# Altium schematic sheet VUB300.SchDoc
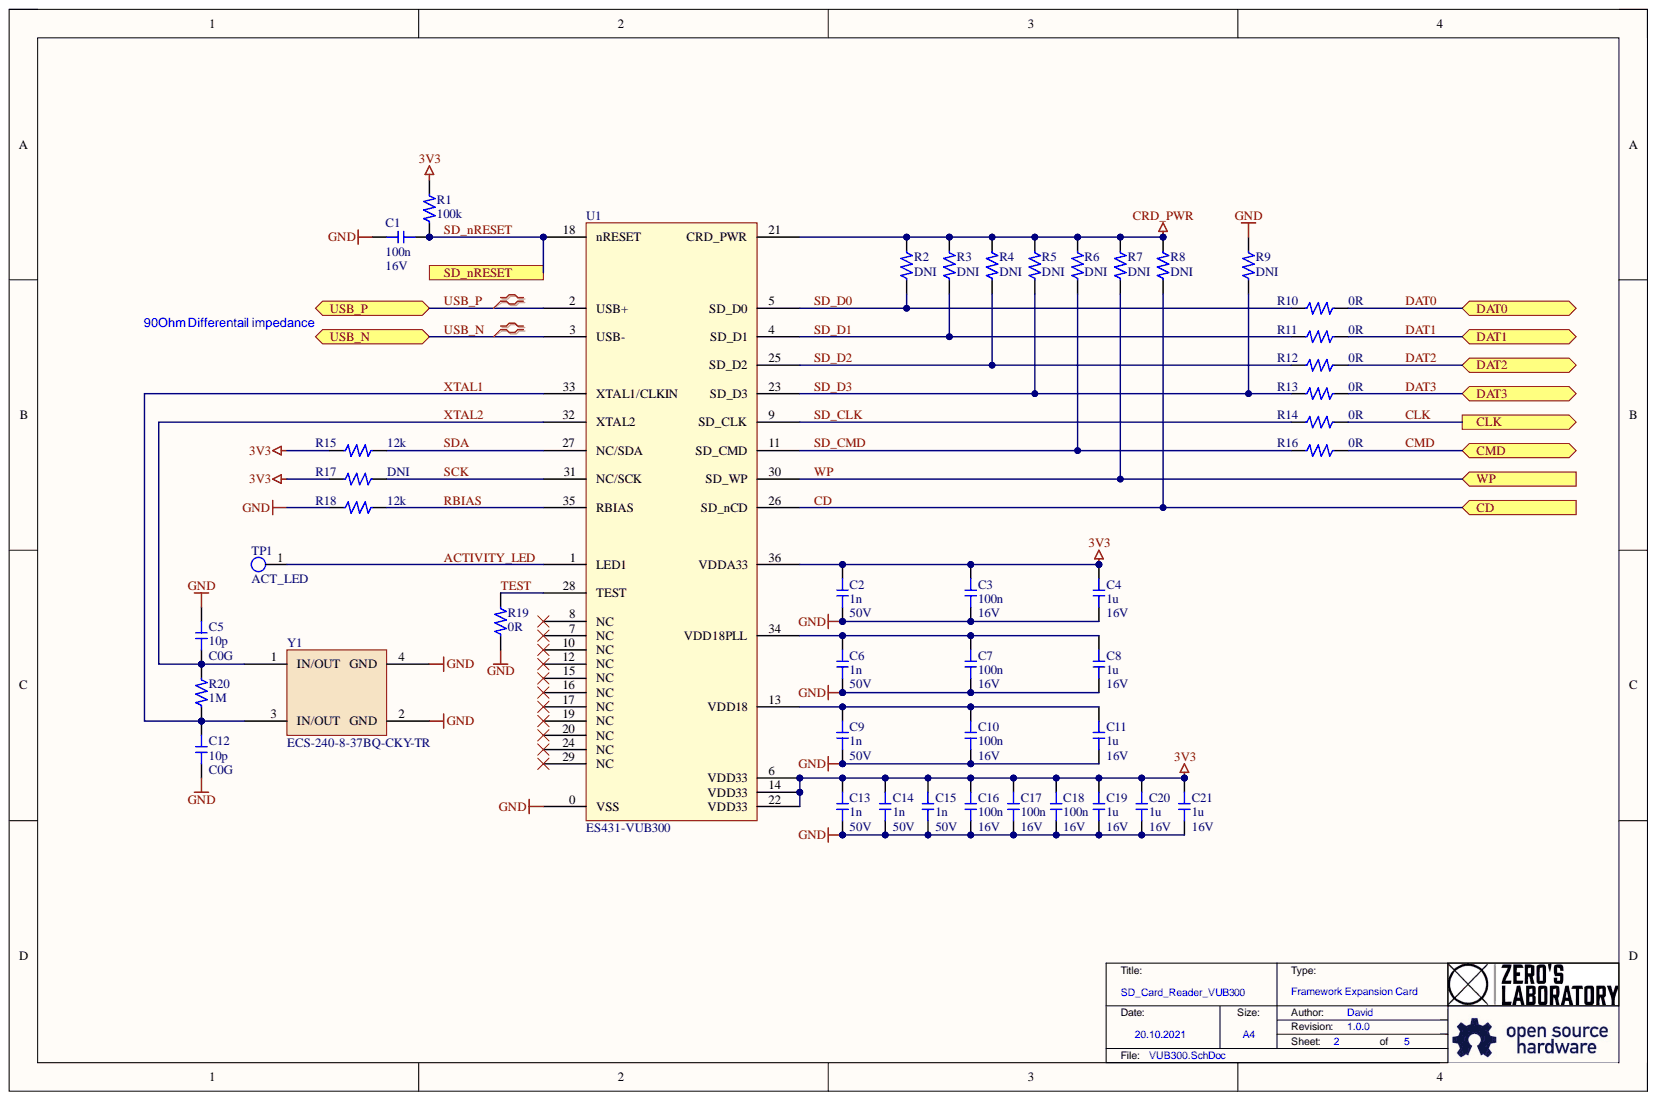
[diagram p0: VUB300.SchDoc]

## Parts
C1  100n  Voltage: 16V  [[0402]_CAPC100X50X50L25]
C2  1n  Voltage: 50V  [[0402]_CAPC100X50X50L25]
C3  100n  Voltage: 16V  [[0402]_CAPC100X50X50L25]
C4  1u  Voltage: 16V  [[0603]_CAPC160X80X100L40]
C5  10p  Dielectric: C0G  [[0402]_CAPC100X50X50L25]
C6  1n  Voltage: 50V  [[0402]_CAPC100X50X50L25]
C7  100n  Voltage: 16V  [[0402]_CAPC100X50X50L25]
C8  1u  Voltage: 16V  [[0603]_CAPC160X80X100L40]
C9  1n  Voltage: 50V  [[0402]_CAPC100X50X50L25]
C10  100n  Voltage: 16V  [[0402]_CAPC100X50X50L25]
C11  1u  Voltage: 16V  [[0603]_CAPC160X80X100L40]
C12  10p  Dielectric: C0G  [[0402]_CAPC100X50X50L25]
C13  1n  Voltage: 50V  [[0402]_CAPC100X50X50L25]
C14  1n  Voltage: 50V  [[0402]_CAPC100X50X50L25]
C15  1n  Voltage: 50V  [[0402]_CAPC100X50X50L25]
C16  100n  Voltage: 16V  [[0402]_CAPC100X50X50L25]
C17  100n  Voltage: 16V  [[0402]_CAPC100X50X50L25]
C18  100n  Voltage: 16V  [[0402]_CAPC100X50X50L25]
C19  1u  Voltage: 16V  [[0603]_CAPC160X80X100L40]
C20  1u  Voltage: 16V  [[0603]_CAPC160X80X100L40]
C21  1u  Voltage: 16V  [[0603]_CAPC160X80X100L40]
R1  100k  [[0402]_RESC100X50X35L25]
R2  DNI  [[0402]_RESC100X50X35L25]
R3  DNI  [[0402]_RESC100X50X35L25]
R4  DNI  [[0402]_RESC100X50X35L25]
R5  DNI  [[0402]_RESC100X50X35L25]
R6  DNI  [[0402]_RESC100X50X35L25]
R7  DNI  [[0402]_RESC100X50X35L25]
R8  DNI  [[0402]_RESC100X50X35L25]
R9  DNI  [[0402]_RESC100X50X35L25]
R10  0R  [[0402]_RESC100X50X35L25]
R11  0R  [[0402]_RESC100X50X35L25]
R12  0R  [[0402]_RESC100X50X35L25]
R13  0R  [[0402]_RESC100X50X35L25]
R14  0R  [[0402]_RESC100X50X35L25]
R15  12k  [[0402]_RESC100X50X35L25]
R16  0R  [[0402]_RESC100X50X35L25]
R17  DNI  [[0402]_RESC100X50X35L25]
R18  12k  [[0402]_RESC100X50X35L25]
R19  0R  [[0402]_RESC100X50X35L25]
R20  1M  [[0402]_RESC100X50X35L25]
TP1  ACT_LED  [TP_0.8MM_SMD]  (no BOM)
U1  ES431-VUB300
Y1  ECS-240-8-37BQ-CKY-TR

## Ports
CD, CLK, CMD, DAT0, DAT1, DAT2, DAT3, SD_nRESET, USB_N, USB_P, WP

## Nets
3V3: C2.2 C3.2 C4.2 C13.2 C14.2 C15.2 C16.2 C17.2 C18.2 C19.2 C20.2 C21.2 R1.2 R15.1 R17.1 U1.6(VDD33) U1.14(VDD33) U1.22(VDD33) U1.36(VDDA33)
CRD_PWR: R2.2 R3.2 R4.2 R5.2 R6.2 R7.2 R8.2 U1.21(CRD_PWR)
GND: C1.2 C2.1 C3.1 C4.1 C5.1 C6.1 C7.1 C8.1 C9.1 C10.1 C11.1 C12.2 C13.1 C14.1 C15.1 C16.1 C17.1 C18.1 C19.1 C20.1 C21.1 R9.2 R18.1 R19.1 U1.0(VSS) Y1.2(GND) Y1.4(GND)
ACTIVITY_LED: TP1.1 U1.1(LED1)
CD: R8.1 U1.26(SD_nCD) ; port CD
CLK: R14.2 ; port CLK
CMD: R16.2 ; port CMD
DAT0: R10.2 ; port DAT0
DAT1: R11.2 ; port DAT1
DAT2: R12.2 ; port DAT2
DAT3: R13.2 ; port DAT3
RBIAS: R18.2 U1.35(RBIAS)
SCK: R17.2 U1.31(NC/SCK)
SD_CLK: R14.1 U1.9(SD_CLK)
SD_CMD: R6.1 R16.1 U1.11(SD_CMD)
SD_D0: R2.1 R10.1 U1.5(SD_D0)
SD_D1: R3.1 R11.1 U1.4(SD_D1)
SD_D2: R4.1 R12.1 U1.25(SD_D2)
SD_D3: R5.1 R9.1 R13.1 U1.23(SD_D3)
SD_nRESET: C1.1 R1.1 U1.18(nRESET) ; port SD_nRESET
SDA: R15.2 U1.27(NC/SDA)
TEST: R19.2 U1.28(TEST)
USB_N: U1.3(USB-) ; port USB_N
USB_P: U1.2(USB+) ; port USB_P
WP: R7.1 U1.30(SD_WP) ; port WP
XTAL1: C12.1 R20.2 U1.33(XTAL1/CLKIN) Y1.3(IN/OUT)
XTAL2: C5.2 R20.1 U1.32(XTAL2) Y1.1(IN/OUT)
NetC6_2: C6.2 C7.2 C8.2 U1.34(VDD18PLL)
NetC9_2: C9.2 C10.2 C11.2 U1.13(VDD18)

Not connected: U1 7(NC) 8(NC) 10(NC) 12(NC) 15(NC) 16(NC) 17(NC) 19(NC) 20(NC) 24(NC) 29(NC)

## Library data of the parts
C1 C3 C7 C10 C16-C18 = Capacitor: Manufacturer: CAL-CHIP ELECTRONICS; MPN: GMC04X7R104K16NT; Capacitance: 100nF; Dielectric: X7R; Tolerance: ±10%; Type: Ceramic; Component Kind: Standard; Description: Capacitor; Library Name: Capacitor.SchLib; Operating Temperature [°C]: -55 to +125; Package: 0402[1005]; Package Type: SMD; Size: 1.00mm x 0.50mm; Value: 100n
C2 C6 C9 C13-C15 = Capacitor: Manufacturer: CAL-CHIP ELECTRONICS; MPN: GMC04X7R102K50NT; Capacitance: 1nF; Dielectric: X7R; Tolerance: ±10%; Type: Ceramic; Component Kind: Standard; Description: Capacitor; Library Name: Capacitor.SchLib; Operating Temperature [°C]: -55 to +125; Package: 0402[1005]; Package Type: SMD; Size: 1.00mm x 0.50mm; Value: 1n
C4 C8 C11 C19-C21 = Capacitor: Manufacturer: CAL-CHIP ELECTRONICS; MPN: GMC10X7R105K16NT; Capacitance: 1uF; Dielectric: X7R; Tolerance: ±10%; Type: Ceramic; Component Kind: Standard; Description: Capacitor; Library Name: Capacitor.SchLib; Operating Temperature [°C]: -55 to +125; Package: 0603[1608]; Package Type: SMD; Size: 1.60mm x 0.80mm; Value: 1u
C5 C12 = Capacitor: Manufacturer: CAL-CHIP ELECTRONICS; MPN: GMC04CG100J50NT; Capacitance: 10pF; Tolerance: ±5%; Type: Ceramic; Voltage: 50V; Component Kind: Standard; Description: Capacitor; Library Name: Capacitor.SchLib; Operating Temperature [°C]: -55 to +155; Package: 0402[1005]; Package Type: SMD; Size: 1.00mm x 0.50mm; Value: 10p
R1 = Resistor: Rated Power: 62.5mW; Resistance: 100kΩ; Tolerance: ±1%; Voltage: 50V; Component Kind: Standard; Description: Resistor; Library Name: Resistor.SchLib; Operating Temperature [°C]: -55 to +155; Package: 0402[1005]; Package Type: SMD; Size: 1.00mm x 0.50mm; Value: 100k
R2-R8 = Resistor: Rated Power: 62.5mW; Resistance: 49.9kΩ; Tolerance: ±1%; Value: 49k9; Voltage: 50V; Component Kind: Standard; Description: Resistor; Library Name: Resistor.SchLib; Operating Temperature [°C]: -55 to +155; Package: 0402[1005]; Package Type: SMD; Size: 1.00mm x 0.50mm
R9 = Resistor: Rated Power: 62.5mW; Resistance: 360kΩ; Tolerance: ±1%; Value: 360k; Voltage: 50V; Component Kind: Standard; Description: Resistor; Library Name: Resistor.SchLib; Operating Temperature [°C]: -55 to +155; Package: 0402[1005]; Package Type: SMD; Size: 1.00mm x 0.50mm
R10-R14 R16 R19 = Resistor: Rated Power: 62.5mW; Resistance: 0Ω; Tolerance: ±1%; Voltage: 50V; Component Kind: Standard; Description: Resistor; Library Name: Resistor.SchLib; Operating Temperature [°C]: -55 to +155; Package: 0402[1005]; Package Type: SMD; Size: 1.00mm x 0.50mm; Value: 0R
R15 R18 = Resistor: Rated Power: 62.5mW; Resistance: 12kΩ; Tolerance: ±1%; Voltage: 50V; Component Kind: Standard; Description: Resistor; Library Name: Resistor.SchLib; Operating Temperature [°C]: -55 to +155; Package: 0402[1005]; Package Type: SMD; Size: 1.00mm x 0.50mm; Value: 12k
R17 = Resistor: Rated Power: 62.5mW; Resistance: 12kΩ; Tolerance: ±1%; Value: 12k; Voltage: 50V; Component Kind: Standard; Description: Resistor; Library Name: Resistor.SchLib; Operating Temperature [°C]: -55 to +155; Package: 0402[1005]; Package Type: SMD; Size: 1.00mm x 0.50mm
R20 = Resistor: Rated Power: 62.5mW; Resistance: 1MΩ; Tolerance: ±1%; Voltage: 50V; Component Kind: Standard; Description: Resistor; Library Name: Resistor.SchLib; Operating Temperature [°C]: -55 to +155; Package: 0402[1005]; Package Type: SMD; Size: 1.00mm x 0.50mm; Value: 1M
TP1 = TestPoint: Test Pad; Library Name: Miscellaneous.SchLib; Value: ACT_LED
U1 = ES431-VUB300: USB to SDIO Host Interface; Manufacturer: Barich; Name: VUB300; Pitch: 0.50mm; Component Kind: Standard; Library Name: Barich.SchLib; MPN: ES431-VUB300; Operating temperature [°C]: -40 to +85; Package: QFN-36; Package Type: SMD; Size: 6.00mm x 6.00mm; Type: SD Card Controller; Value: ES431-VUB300; Web: https://www.barich.co.uk/vub300/
Y1 = ECS-240-8-37BQ-CKY-TR: SMD CRYSTAL 24MHz; Manufacturer: ECS; Freq Stability: 10ppm; Load Capacitance: 8pF; Name: ECX-1637BQ; Pitch: 1.05mm; Component Kind: Standard; Freq: 24MHz; Freq Tolerance: 10ppm; Library Name: ECS.SchLib; MPN: ECS-240-8-37BQ-CKY-TR; Operating temperature [°C]: -30 to +85; Package: OSCCC-4; Package Type: SMD; Size: 2.00mm x 1.60mm; Type: Crystal; Value: ECS-240-8-37BQ-CKY-TR; Web: https://ecsxtal.com/ecx-1637bq
